# Altium schematic sheet MXiU.SchDoc(MXiU)
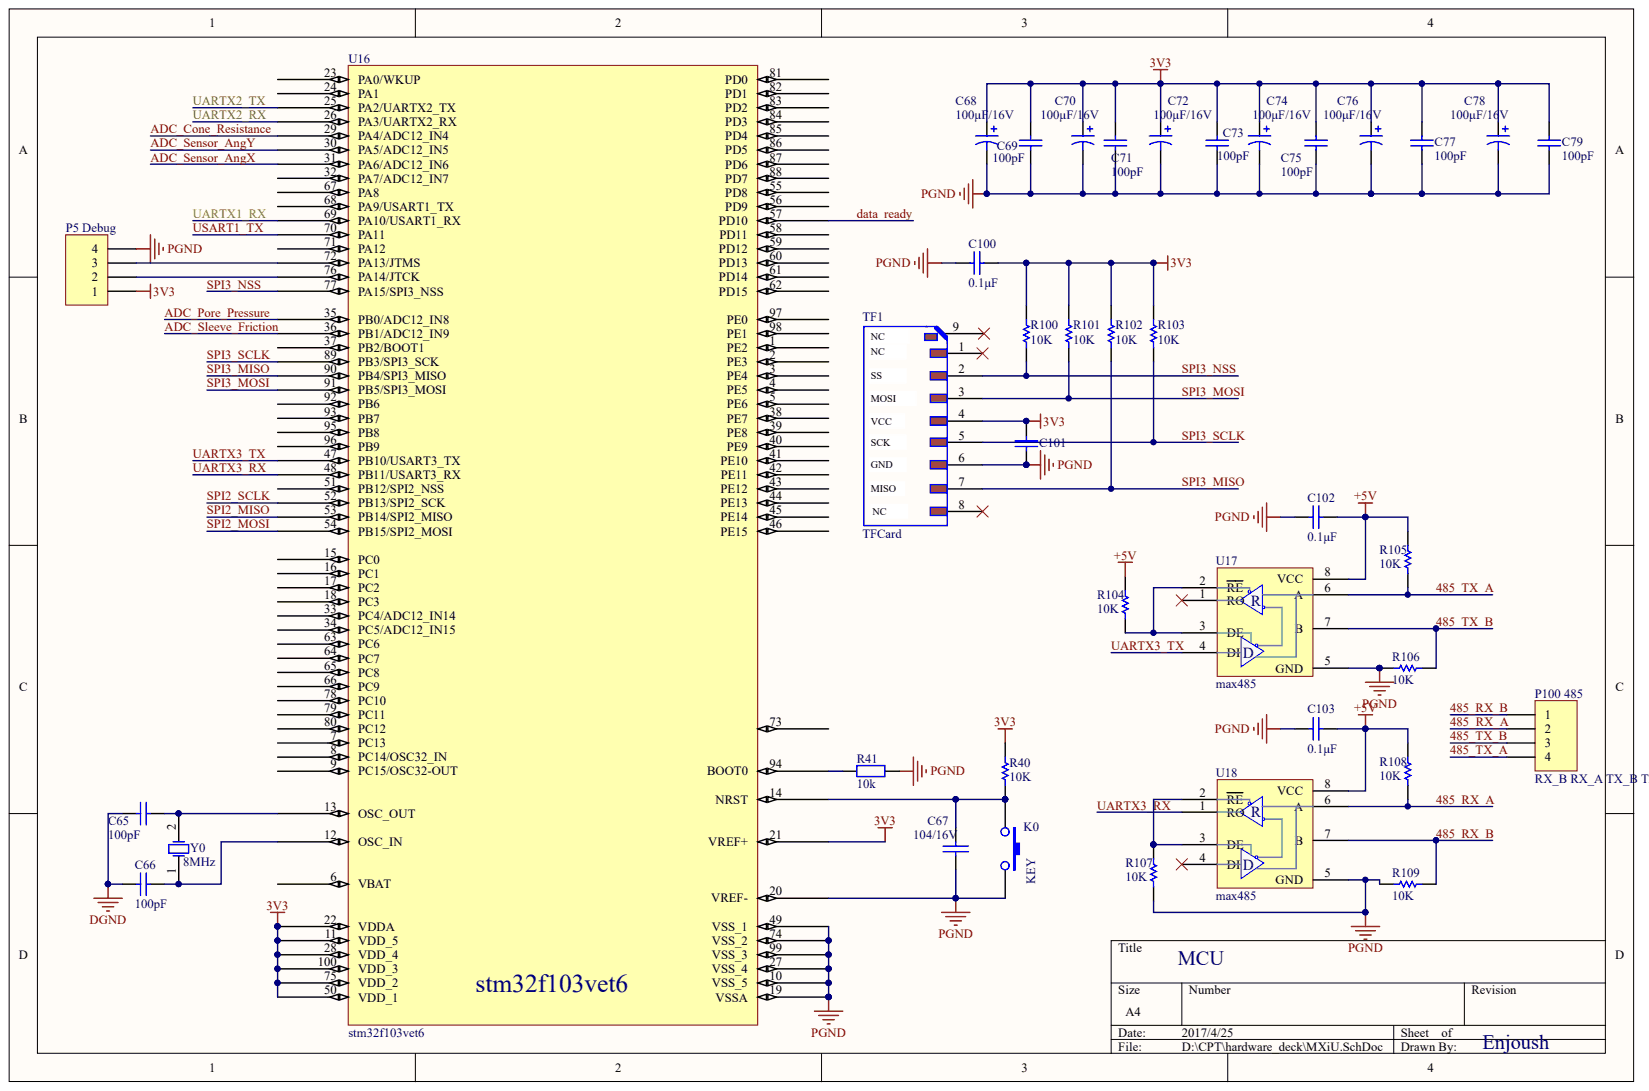
[diagram p0: MXiU.SchDoc]

## Parts
C65  value 100pF  (hidden comment: Cap)
C66  value 100pF  (hidden comment: Cap)
C67  104/16V
C68  100μF/16V  value 100pF
C69  value 100pF  (hidden comment: Cap)
C70  100μF/16V
C71  value 100pF  (hidden comment: Cap)
C72  100μF/16V
C73  value 100pF  (hidden comment: Cap)
C74  100μF/16V  value 100pF
C75  value 100pF  (hidden comment: Cap)
C76  100μF/16V
C77  value 100pF  (hidden comment: Cap)
C78  100μF/16V
C79  value 100pF  (hidden comment: Cap)
C100  0.1μF
C101  0.1μF  (value not drawn)
C102  0.1μF
C103  0.1μF
K0  KEY
P5_Debug  (no value)
P100_485  RX_B RX_A TX_B TX_A  (value not drawn)
R40  10K
R41  10k
R100  10K
R101  10K
R102  10K
R103  10K
R104  10K
R105  10K
R106  10K
R107  10K
R108  10K
R109  10K
TF1  TFCard
U16  stm32f103vet6
U17  max485
U18  max485
Y0  8MHz

## Nets
3V3: C68.1 C69.2 C70.1 C71.2 C72.1 C73.2 C74.1 C75.2 C76.1 C77.2 C78.1 C79.2 C100.1 C101.1 P5_Debug.1 R40.1 R100.1 R101.1 R102.1 R103.1 TF1.4 U16.11(VDD_5) U16.21(VREF+) U16.22(VDDA) U16.28(VDD_4) U16.50(VDD_1) U16.75(VDD_2) U16.100(VDD_3)
+5V: C102.1 C103.1 R104.1 R105.1 R108.1 U17.8(VCC) U18.8(VCC)
DGND: C65.1 C66.1
PGND: C67.2 C68.2 C69.1 C70.2 C71.1 C72.2 C73.1 C74.2 C75.1 C76.2 C77.1 C78.2 C79.1 C100.2 C101.2 C102.2 C103.2 K0.2 P5_Debug.4 R41.1 R106.1 R107.2 R109.1 TF1.6 U16.10(VSS_5) U16.19(VSSA) U16.20(VREF-) U16.27(VSS_4) U16.49(VSS_1) U16.74(VSS_2) U16.99(VSS_3) U17.5(GND) U18.5(GND)
485_RX_A: P100_485.2 R108.2 U18.6(A)
485_RX_B: P100_485.1 R109.2 U18.7(B)
485_TX_A: P100_485.4 R105.2 U17.6(A)
485_TX_B: P100_485.3 R106.2 U17.7(B)
ADC_CONE_RESISTANCE: U16.29(PA4/ADC12_IN4)
ADC_PORE_PRESSURE: U16.35(PB0/ADC12_IN8)
ADC_SENSOR_ANGX: U16.31(PA6/ADC12_IN6)
ADC_SENSOR_ANGY: U16.30(PA5/ADC12_IN5)
ADC_SLEEVE_FRICTION: U16.36(PB1/ADC12_IN9)
DATA_READY: U16.57(PD10)
SPI2_MISO: U16.53(PB14/SPI2_MISO)
SPI2_MOSI: U16.54(PB15/SPI2_MOSI)
SPI2_SCLK: U16.52(PB13/SPI2_SCK)
SPI3_MISO: R102.2 TF1.7 U16.90(PB4/SPI3_MISO)
SPI3_MOSI: R101.2 TF1.3 U16.91(PB5/SPI3_MOSI)
SPI3_NSS: R100.2 TF1.2 U16.77(PA15/SPI3_NSS)
SPI3_SCLK: R103.2 TF1.5 U16.89(PB3/SPI3_SCK)
UARTX1_RX: U16.69(PA10/USART1_RX)
UARTX2_RX: U16.26(PA3/UARTX2_RX)
UARTX2_TX: U16.25(PA2/UARTX2_TX)
UARTX3_RX: U16.48(PB11/USART3_RX) U18.1(RO)
UARTX3_TX: U16.47(PB10/USART3_TX) U17.4(DI)
USART1_TX: U16.70(PA11)
NetC65_2: C65.2 U16.13(OSC_OUT) Y0.2
NetC66_2: C66.2 U16.12(OSC_IN) Y0.1
NetC67_1: C67.1 K0.1 R40.2 U16.14(NRST)
NetP5 Debug_2: P5_Debug.2 U16.76(PA14/JTCK)
NetP5 Debug_3: P5_Debug.3 U16.72(PA13/JTMS)
NetR41_2: R41.2 U16.94(BOOT0)
NetR104_2: R104.2 U17.2(RE) U17.3(DE)
NetR107_1: R107.1 U18.2(RE) U18.3(DE)

Not connected: TF1 1 8 9; U16 1(PE2) 2(PE3) 3(PE4) 4(PE5) 5(PE6) 6(VBAT) 7(PC13) 8(PC14/OSC32_IN) 9(PC15/OSC32-OUT) 15(PC0) 16(PC1) 17(PC2) 18(PC3) 23(PA0/WKUP) 24(PA1) 32(PA7/ADC12_IN7) 33(PC4/ADC12_IN14) 34(PC5/ADC12_IN15) 37(PB2/BOOT1) 38(PE7) 39(PE8) 40(PE9) 41(PE10) 42(PE11) 43(PE12) 44(PE13) 45(PE14) 46(PE15) 51(PB12/SPI2_NSS) 55(PD8) 56(PD9) 58(PD11) 59(PD12) 60(PD13) 61(PD14) 62(PD15) 63(PC6) 64(PC7) 65(PC8) 66(PC9) 67(PA8) 68(PA9/USART1_TX) 71(PA12) 73 78(PC10) 79(PC11) 80(PC12) 81(PD0) 82(PD1) 83(PD2) 84(PD3) 85(PD4) 86(PD5) 87(PD6) 88(PD7) 92(PB6) 93(PB7) 95(PB8) 96(PB9) 97(PE0) 98(PE1); U17 1(RO); U18 4(DI)

## Library data of the parts
C70 C72 C76 C78 C100-C103 = (no symbol name): Value: 100pF; Published: 8-Jun-2000; Publisher: Altium Limited
  C70 C72 C76 C78: LatestRevisionDate: 29-May-2009; LatestRevisionNote: IPC-7351 Footprint Added.; PackageReference: C0805; PackageDescription: Chip Capacitor
  C100-C103: LatestRevisionDate: 17-Jul-2002; LatestRevisionNote: Re-released for DXP Platform.; PackageReference: RAD-0.3; PackageDescription: Radial Cap, Thru-Hole; 2 Leads; 0.3 in Pin Spacing
R40 R41 R100-R109 = (no symbol name): Value: 1K; Published: 8-Jun-2000; LatestRevisionDate: 17-Jul-2002; LatestRevisionNote: Re-released for DXP Platform.; Publisher: Altium Limited
  R40 R100-R109: PackageReference: AXIAL-0.5; PackageDescription: Axial Device, Thru-Hole; 2 Leads; 0.5 in Pin Spacing
  R41: PackageReference: AXIAL-0.4; PackageDescription: Axial Device, Thru-Hole; 2 Leads; 0.4 in Pin Spacing
C65-C69 C71 C73-C75 C77 C79 K0 P5_Debug P100_485 TF1 U16-U18 Y0 = (no symbol name)
  C65 C66 C69 C71 C73 C75 C77 C79: Published: 8-Jun-2000; LatestRevisionDate: 17-Jul-2002; LatestRevisionNote: Re-released for DXP Platform.; PackageReference: RAD-0.3; PackageDescription: Radial Cap, Thru-Hole; 2 Leads; 0.3 in Pin Spacing
  C68 C74: Published: 8-Jun-2000; LatestRevisionDate: 29-May-2009; LatestRevisionNote: IPC-7351 Footprint Added.; PackageReference: C0805; PackageDescription: Chip Capacitor
  P5_Debug P100_485: LatestRevisionDate: 17-Jul-2002; LatestRevisionNote: Re-released for DXP Platform.
  Y0: Published: 8-Jun-2000; LatestRevisionDate: 17-Jul-2002; LatestRevisionNote: Re-released for DXP Platform.; PackageReference: R38; PackageDescription: Crystal, Thru-Hole; 2 Leads; Body 3.1 x 8.2 mm (Dia.xH)
  C65 C66 C68 C69 C71 C73-C75 C77 C79 P5_Debug P100_485 Y0: Publisher: Altium Limited
